# Altium schematic sheet BLDC_Motor_Reversal_Rev2.SchDoc
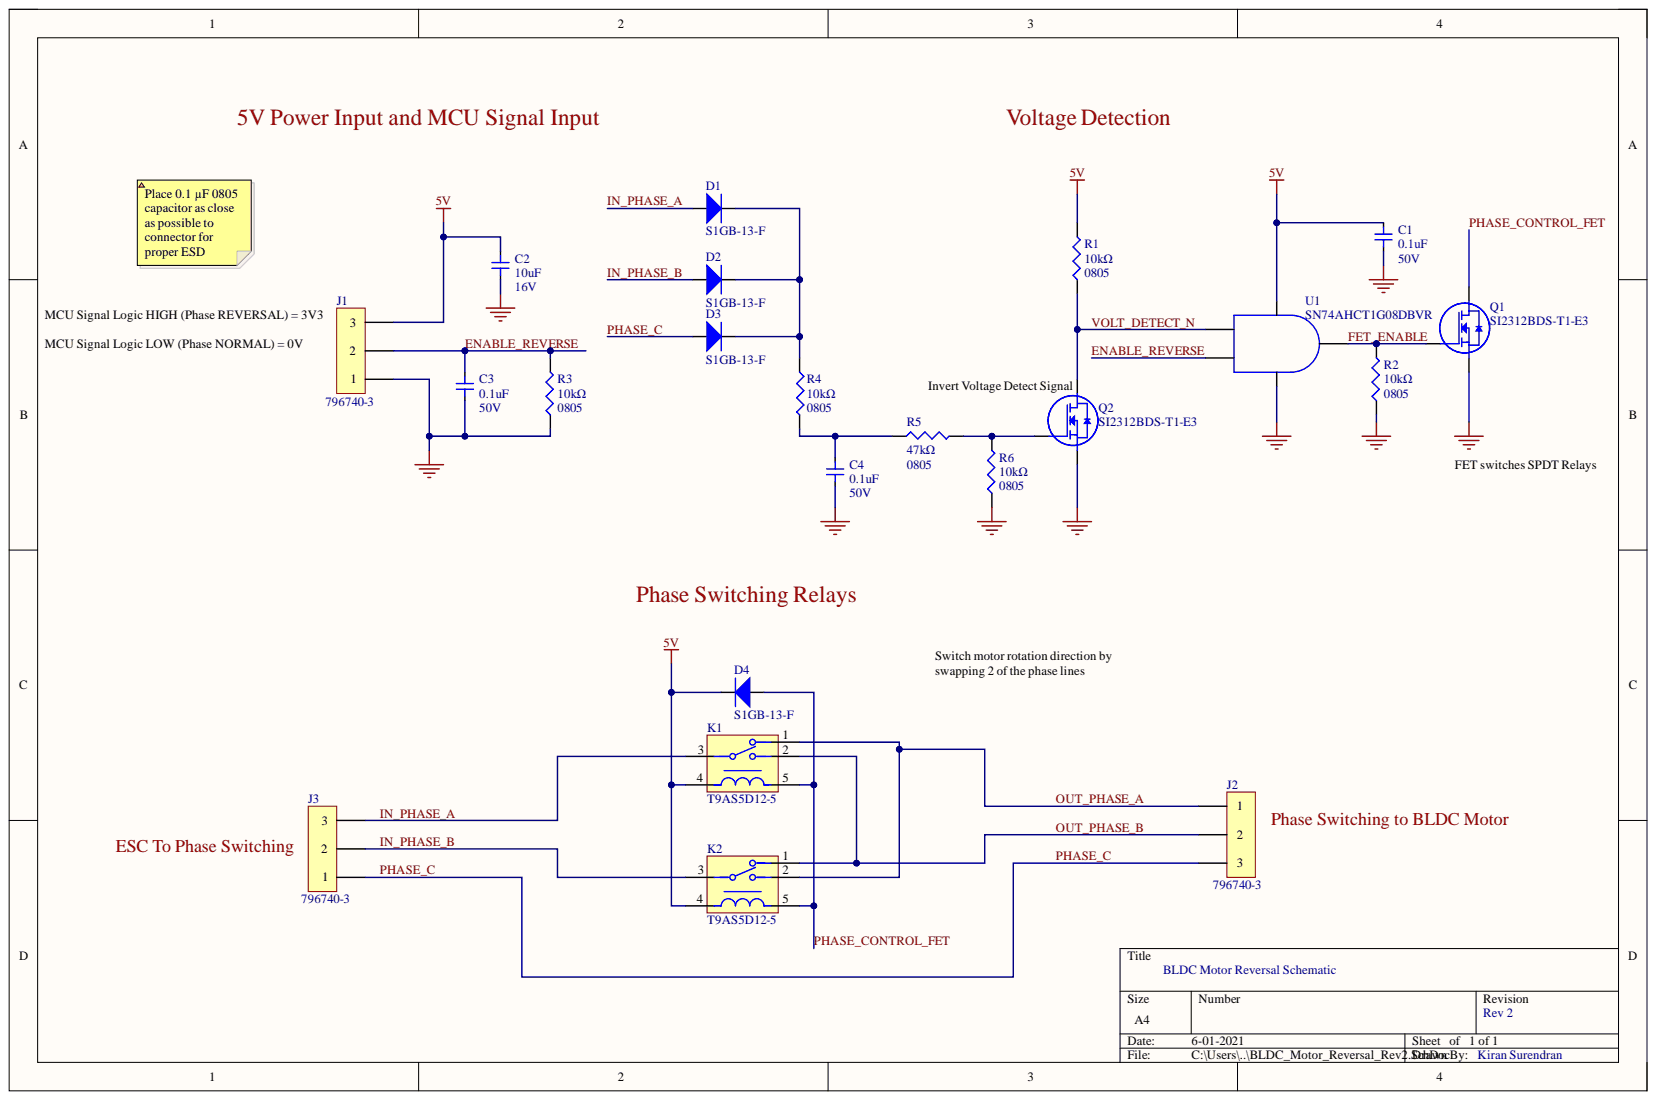
[diagram p0: BLDC_Motor_Reversal_Rev2.SchDoc]

## Parts
C1  Capacitance: 0.1uF  Voltage Rating: 50V  [C0805C104K5RACTU]  (hidden comment: C0805C104K5RACTU)
C2  Capacitance: 10uF  Voltage Rating: 16V  [C0805C106K4PACTU]  (hidden comment: C0805C106K4PACTU)
C3  Capacitance: 0.1uF  Voltage Rating: 50V  [C0805C104K5RACTU]  (hidden comment: C0805C104K5RACTU)
C4  Capacitance: 0.1uF  Voltage Rating: 50V  [C0805C104K5RACTU]  (hidden comment: C0805C104K5RACTU)
D1  S1GB-13-F  [S1GB-13-F]
D2  S1GB-13-F  [S1GB-13-F]
D3  S1GB-13-F  [S1GB-13-F]
D4  S1GB-13-F  [S1GB-13-F]
J1  796740-3  [796740-3]
J2  796740-3  [796740-3]
J3  796740-3  [796740-3]
K1  T9AS5D12-5  [T9AS5D12-5]
K2  T9AS5D12-5  [T9AS5D12-5]
Q1  SI2312BDS-T1-E3  [SI2312BDS-T1-E3]
Q2  SI2312BDS-T1-E3  [SI2312BDS-T1-E3]
R1  Package: 0805  Resistance: 10kΩ  [RC0805JR-0710KL]  (hidden comment: RC0805JR-0710KL)
R2  Package: 0805  Resistance: 10kΩ  [RC0805JR-0710KL]  (hidden comment: RC0805JR-0710KL)
R3  Package: 0805  Resistance: 10kΩ  [RC0805JR-0710KL]  (hidden comment: RC0805JR-0710KL)
R4  Package: 0805  Resistance: 10kΩ  [RC0805JR-0710KL]  (hidden comment: RC0805JR-0710KL)
R5  Package: 0805  Resistance: 47kΩ  [RC0805JR-0747KL]  (hidden comment: RC0805JR-0747KL)
R6  Package: 0805  Resistance: 10kΩ  [RC0805JR-0710KL]  (hidden comment: RC0805JR-0710KL)
U1  AND Gate  [SN74AHCT1G08DBVR]  (value not drawn)

## Nets
5V: C1.2 C2.2 D4.1 J1.3 K1.4 K2.4 R1.2 U1.5
GND: C1.1 C2.1 C3.1 C4.1 J1.1 Q1.2 Q2.2 R2.1 R3.1 R6.1 U1.3
ENABLE_REVERSE: C3.2 J1.2 R3.2 U1.2
FET_ENABLE: Q1.1 R2.2 U1.4
IN_PHASE_A: D1.1 J3.3 K1.3
IN_PHASE_B: D2.1 J3.2 K2.3
OUT_PHASE_A: J2.1 K1.1 K2.2
OUT_PHASE_B: J2.2 K1.2 K2.1
PHASE_C: D3.1 J2.3 J3.1
PHASE_CONTROL_FET: D4.2 K1.5 K2.5 Q1.3
VOLT_DETECT_N: Q2.3 R1.1 U1.1
NetC4_2: C4.2 R4.2 R5.1
NetD1_2: D1.2 D2.2 D3.2 R4.1
NetQ2_1: Q2.1 R5.2 R6.2

## Library data of the parts
C1 C3 C4 = C0805C104K5RACTU: Cap Ceramic 0.1uF 50V X7R 10% SMD 2.0 x 1.2 mm 125 C Paper T/R; Manufacturer 1: KEMET; Case/Package: 0805; Component Kind: Standard; Library Name: BLDC_Motor_SchLib.SchLib; Manufacturer Part Number 1: C0805C104K5RACTU; Supplier 1: Digi-Key; Supplier Part Number 1: 399-1170-1-ND
C2 = C0805C106K4PACTU: CERAMIC CAPACITOR, 10UF, 16V, X5R, 10%, 0805; Manufacturer 1: KEMET; Case/Package: 0805; Component Kind: Standard; Library Name: BLDC_Motor_Lib.SchLib; Manufacturer Part Number 1: C0805C106K4PACTU; Supplier 1: Digi-Key; Supplier Part Number 1: 399-8012-1-ND
D1-D4 = S1GB-13-F: Flyback Diode for SPDT; Manufacturer 1: Diodes Incorporated; IFSM: 8.0A; VRRM: 325V; Component Kind: Standard; Manufacturer Part Number 1: S1GB-13-F; Supplier 1: Digi-Key; Supplier Part Number 1: S1GB-FDICT-ND
  D1-D3: Library Name: BLDC_Motor_Lib.SchLib
  D4: Library Name: BLDC_Motor_SchLib.SchLib
J1-J3 = 796740-3: TERM BLK 3P SIDE ENT 6.35MM PCB; Manufacturer 1: TE Connectivity AMP Connectors; Component Kind: Standard; Library Name: BLDC_Motor_Lib.SchLib; Manufacturer Part Number 1: 796740-3; Supplier 1: Digi-Key; Supplier Part Number 1: A98276-ND
K1 K2 = T9AS5D12-5: RELAY GEN PURPOSE SPDT 20A 5V; Manufacturer 1: TE Connectivity Potter & Brumfield Relays; Coil Current: 200mA; Contact Voltage Rating (AC): 277V; Current Rating: 30A; Coil Voltage (DC): 5V; Component Kind: Standard; Contact Current Rating: 20A; Library Name: BLDC_Motor_Lib.SchLib; Manufacturer Part Number 1: T9AS5D12-5; Supplier 1: Digi-Key; Supplier Part Number 1: T9AS5D12-5-ND; Voltage Rating (DC): 5V
Q1 Q2 = SI2312BDS-T1-E3: MOSFET; Manufacturer 1: Vishay Siliconix; Component Kind: Standard; Manufacturer Part Number 1: SI2312BDS-T1-E3; Supplier 1: Digi-Key; Supplier Part Number 1: SI2312BDS-T1-E3CT-ND
  Q1: Library Name: BLDC_Motor_Lib.SchLib
  Q2: Library Name: BLDC_Motor_SchLib.SchLib
R1-R4 R6 = RC0805JR-0710KL: Res Thick Film 0805 10K Ohm 5% 1/8W ±100ppm/°C Molded SMD Paper T/R; Manufacturer 1: Yageo; Component Kind: Standard; Library Name: BLDC_Motor_SchLib.SchLib; Manufacturer Part Number 1: RC0805JR-0710KL; Supplier 1: Digi-Key; Supplier Part Number 1: 311-10KARCT-ND; Tolerance: 5%
R5 = RC0805JR-0747KL: 47 kOhms ±5% 0.125W, 1/8W Chip Resistor 0805 (2012 Metric) Moisture Resistant Thick Film; Manufacturer 1: Yageo; Component Kind: Standard; Library Name: BLDC_Motor_SchLib.SchLib; Manufacturer Part Number 1: RC0805JR-0747KL; Supplier 1: Digi-Key; Supplier Part Number 1: 311-47KARCT-ND
U1 = SN74AHCT1G08DBVR: AND Gate IC 1 Channel - SOT-23-5; Manufacturer 1: Texas Instruments; Component Kind: Standard; Library Name: BLDC_Motor_SchLib.SchLib; Manufacturer Part Number 1: SN74AHCT1G08DBVR; Supplier 1: Digi-Key; Supplier Part Number 1: 296-1113-1-ND
